# Altium schematic sheet GP8102STEST.SchDoc
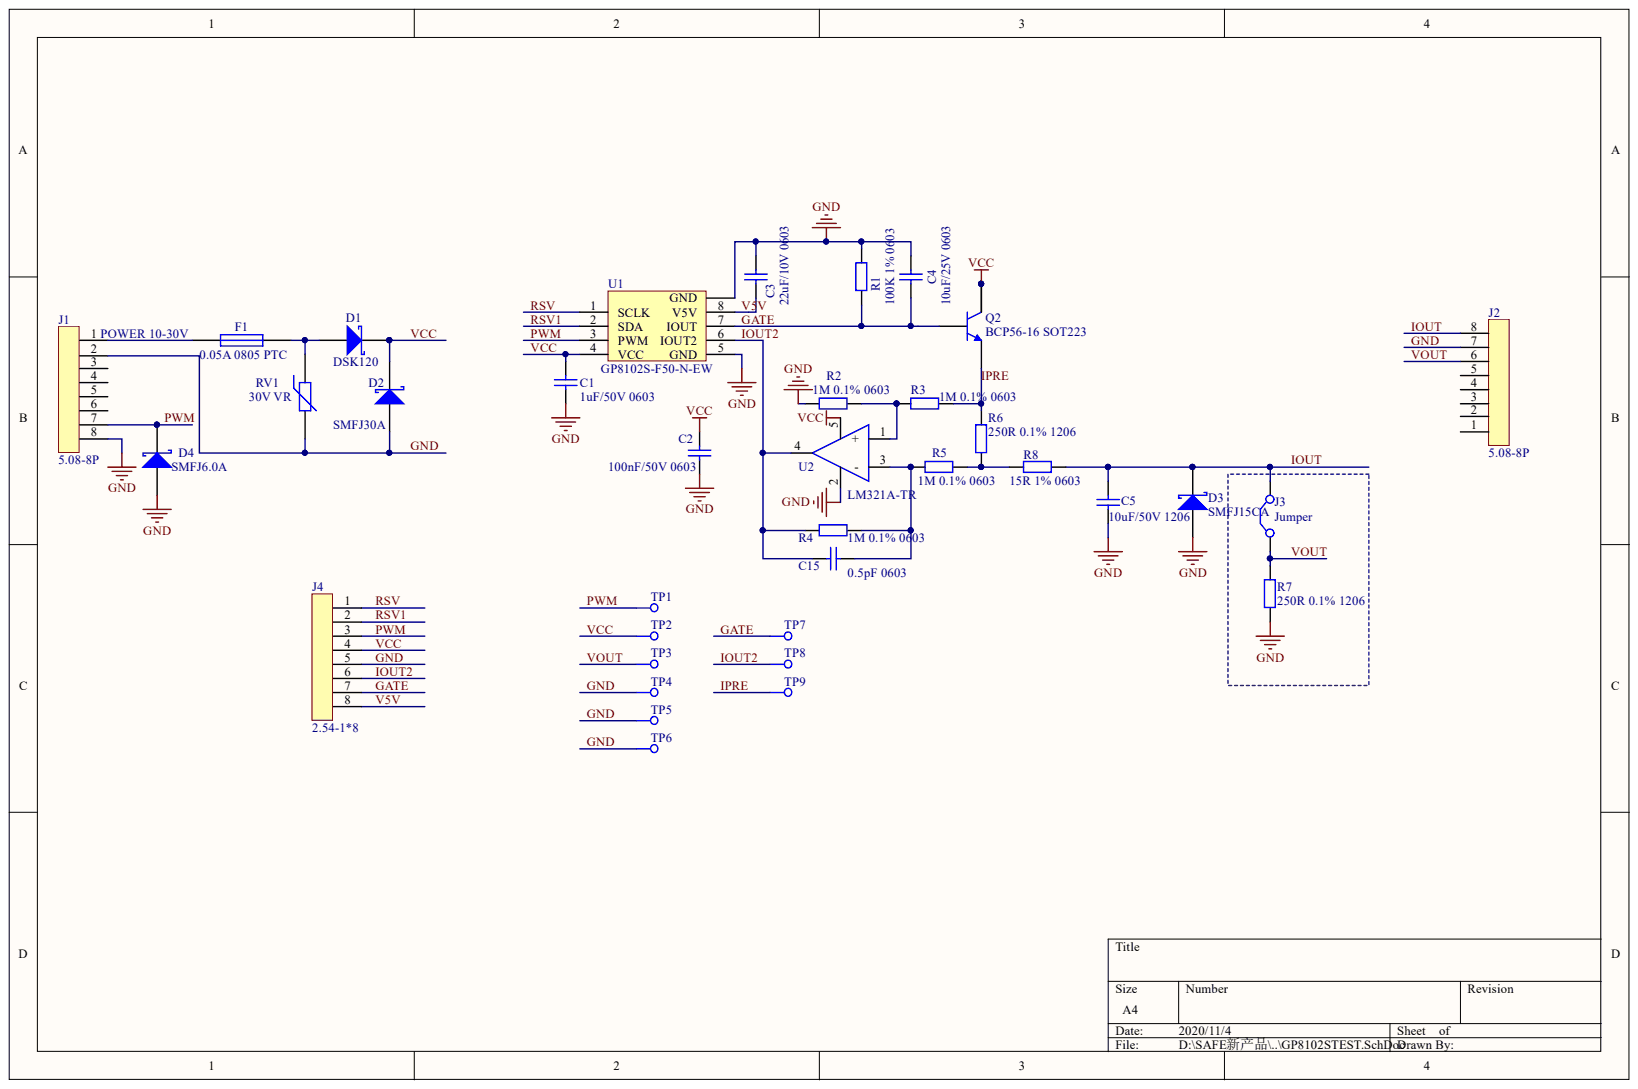
[diagram p0: GP8102STEST.SchDoc]

## Parts
C1  1uF/50V 0603  [CAP_0603]
C2  100nF/50V 0603  [CAP_0603]
C3  22uF/10V 0603  [CAP_0603]
C4  10uF/25V 0603  [CAP_0603]
C5  value 10uF/50V 1206  [CAP_1206]  (hidden comment: 10nF/50V 1206)
C15  0.5pF 0603  [CAP_0603]
D1  DSK120  [SODFL370X120-2N]
D2  SMFJ30A  [SODFL370X120-2N]
D3  SMFJ15CA  [SODFL370X120-2N]
D4  SMFJ6.0A  [SODFL370X120-2N]
F1  0.05A 0805 PTC  [RES_0805]
J1  5.08-8P  [5.08-8P]
J2  5.08-8P  [5.08-8P]
J3  Jumper  [2.54-1*2]
J4  2.54-1*8  [2.54-1*8]
Q2  BCP56-16 SOT223  [NPN_SOT223]
R1  100K 1% 0603  [RES_0603]
R2  1M 0603  value 1M 0.1% 0603  [RES_0603]
R3  1M 0603  value 1M 0.1% 0603  [RES_0603]
R4  value 1M 0.1% 0603  [RES_0603]  (hidden comment: 1M 0603)
R5  1M 0603  value 1M 0.1% 0603  [RES_0603]
R6  250R 0.1% 1206  [RES_1206]
R7  250R 0.1% 1206  [RES_1206]
R8  value 15R 1% 0603  [RES_0603]  (hidden comment: 1M 0603)
RV1  30V VR  [RES_0805]
TP1  PWM  [Hole_Test]
TP2  VCC  [Hole_Test]
TP3  VOUT  [Hole_Test]
TP4  GND  [Hole_Test]
TP5  GND  [Hole_Test]
TP6  GND  [Hole_Test]
TP7  IOUT_7  [Hole_Test]  (value not drawn)
TP8  IOUT2  [Hole_Test]
TP9  IPRE  [Hole_Test]
U1  GP8102S-F50-N-EW  [ESOIC-8]
U2  LM321A-TR  [SOT23-5]

## Nets
GATE: C4.1 J4.7 Q2.1 R1.2 TP7.1 U1.7(IOUT)
GND: C1.2 C2.2 C3.2 C4.2 C5.1 D2.1 D3.1 D4.1 J1.2 J1.8 J2.7 J4.5 R1.1 R2.2 R7.1 RV1.2 TP4.1 TP5.1 TP6.1 U1.5(GND) U1.9(GND) U2.2
IOUT: C5.2 D3.2 J2.8 J3.2 R8.2
IOUT2: C15.1 J4.6 R4.1 TP8.1 U1.6(IOUT2) U2.4
IPRE: Q2.3 R3.1 R6.2 TP9.1
PWM: D4.2 J1.7 J4.3 TP1.1 U1.3(PWM)
RSV: J4.1 U1.1(SCLK)
RSV1: J4.2 U1.2(SDA)
V5V: C3.1 J4.8 U1.8(V5V)
VCC: C1.1 C2.1 D1.2 D2.2 J4.4 Q2.2 Q2.4 TP2.1 U1.4(VCC) U2.5
VOUT: J2.6 J3.1 R7.2 TP3.1
NetC15_2: C15.2 R4.2 R5.2 U2.3(-)
NetD1_1: D1.1 F1.2 RV1.1
NetF1_1: F1.1 J1.1
NetR2_1: R2.1 R3.2 U2.1(+)
NetR5_1: R5.1 R6.1 R8.1

Not connected: J1 3 4 5 6; J2 1 2 3 4 5

## Library data of the parts
C1-C4 = Cap: Component Kind: Standard; LatestRevisionDate: 17-Jul-2002; LatestRevisionNote: Re-released for DXP Platform.; Library Name: Miscellaneous Devices.IntLib; PackageDescription: Radial Cap, Thru-Hole; 2 Leads; 0.3 in Pin Spacing; PackageReference: RAD-0.3; Published: 8-Jun-2000; Signal Integrity: Cap; Simulation: CAP; Publisher: Altium Limited
  C1: Description: 1uF/50V 0603; Value: 1uF/50V 0603
  C2: Description: 100nF/50V 0603; Value: 100nF/50V 0603
  C3: Description: 22uF/10V 0603; Value: 22uF/10V 0603
  C4: Description: 10uF/25V 0603
C5 = Cap: 10uF/50V 1206; Component Kind: Standard; LatestRevisionDate: 17-Jul-2002; LatestRevisionNote: Re-released for DXP Platform.; Library Name: Miscellaneous Devices.IntLib; PackageDescription: Radial Cap, Thru-Hole; 2 Leads; 0.3 in Pin Spacing; PackageReference: RAD-0.3; Published: 8-Jun-2000; Signal Integrity: Cap; Simulation: CAP; Value: 10uF/50V 1206; Publisher: Altium Limited
C15 = Cap: 1pF 0603; Component Kind: Standard; LatestRevisionDate: 17-Jul-2002; LatestRevisionNote: Re-released for DXP Platform.; Library Name: Miscellaneous Devices.IntLib; PackageDescription: Radial Cap, Thru-Hole; 2 Leads; 0.3 in Pin Spacing; PackageReference: RAD-0.3; Published: 8-Jun-2000; Signal Integrity: Cap; Simulation: CAP; Value: 0.5pF 0603; Publisher: Altium Limited
D1-D4 = D Schottky: Code_JEDEC: DO-214-AA; Component Kind: Standard; LatestRevisionDate: 17-Jul-2002; LatestRevisionNote: Re-released for DXP Platform.; Library Name: Miscellaneous Devices.IntLib; PackageDescription: DO-214-AA/SMB; 2 C-Bend Leads; Body 5.3 x 3.6 mm, inc. leads (LxW); PackageReference: SMB; Published: 8-Jun-2000; Signal Integrity: D Schottky; Simulation: SKYDIODE; Publisher: Altium Limited
  D1: Description: DSK120
  D2: Description: SMFJ30A
  D3: Description: SMFJ15CA
  D4: Description: SMFJ6.0A
F1 = Fuse 1: Component Kind: Standard; Description: 0.05A 0805 PTC; LatestRevisionDate: 17-Jul-2002; LatestRevisionNote: Re-released for DXP Platform.; Library Name: Miscellaneous Devices.IntLib; PackageDescription: Thru-Hole; 2 Leads; PackageReference: PIN-W2/E2.8; Published: 8-Jun-2000; Simulation: FUSE; Publisher: Altium Limited
J3 = Jumper: Jumper Wire; Component Kind: Standard; LatestRevisionDate: 17-Jul-2002; LatestRevisionNote: Re-released for DXP Platform.; Library Name: Miscellaneous Devices.IntLib; PackageDescription: Radial Cap, Thru-Hole; 2 Leads; 0.2 in Pin Spacing; PackageReference: RAD-0.2; Published: 24-Mar-1999; Publisher: Altium Limited
J4 = 5.08-8P: Component Kind: Standard; Description: 5.08-8P; Library Name: SFM.SchLib
Q2 = NPN_SOT223: Component Kind: Standard; Description: BCP56-16 SOT223; Library Name: SFM.SchLib
R1-R8 = Res2: Component Kind: Standard; LatestRevisionDate: 17-Jul-2002; LatestRevisionNote: Re-released for DXP Platform.; Library Name: Miscellaneous Devices.IntLib; PackageDescription: Axial Device, Thru-Hole; 2 Leads; 0.4 in Pin Spacing; PackageReference: AXIAL-0.4; Published: 8-Jun-2000; Signal Integrity: Res; Simulation: RESISTOR; Publisher: Altium Limited
  R1: Description: 100K 1% 0603; Value: 100K 1% 0603
  R2-R5 R8: Description: 1M 0603
  R6 R7: Description: 250R 0.1% 1206; Value: 250R 0.1% 1206
RV1 = Res Varistor: Code_IPC: RESC2012; Component Kind: Standard; Description: 30V VR; LatestRevisionDate: 29-May-2009; LatestRevisionNote: IPC-7351 Footprint Added.; Library Name: Miscellaneous Devices.IntLib; PackageDescription: Chip Resistor 0805 Case Size; PackageReference: 6-0805; PackageVersion: Mar-2003; Published: 24-Mar-1999; Publisher: Altium Limited
TP1-TP9 = Hole: TP; Component Kind: Standard; Library Name: WXL_Integrated_Library.IntLib
U1 = GP810x: Component Kind: Standard; Library Name: SFM.SchLib
U2 = LM321: LM321 SOT23-5; Component Kind: Standard; Library Name: SFM.SchLib
J1 J2 = 5.08-8P: Component Kind: Standard; Description: 5.08-8P; Library Name: SFM.SchLib
